# Altium schematic sheet Heart.SchDoc(Heart)
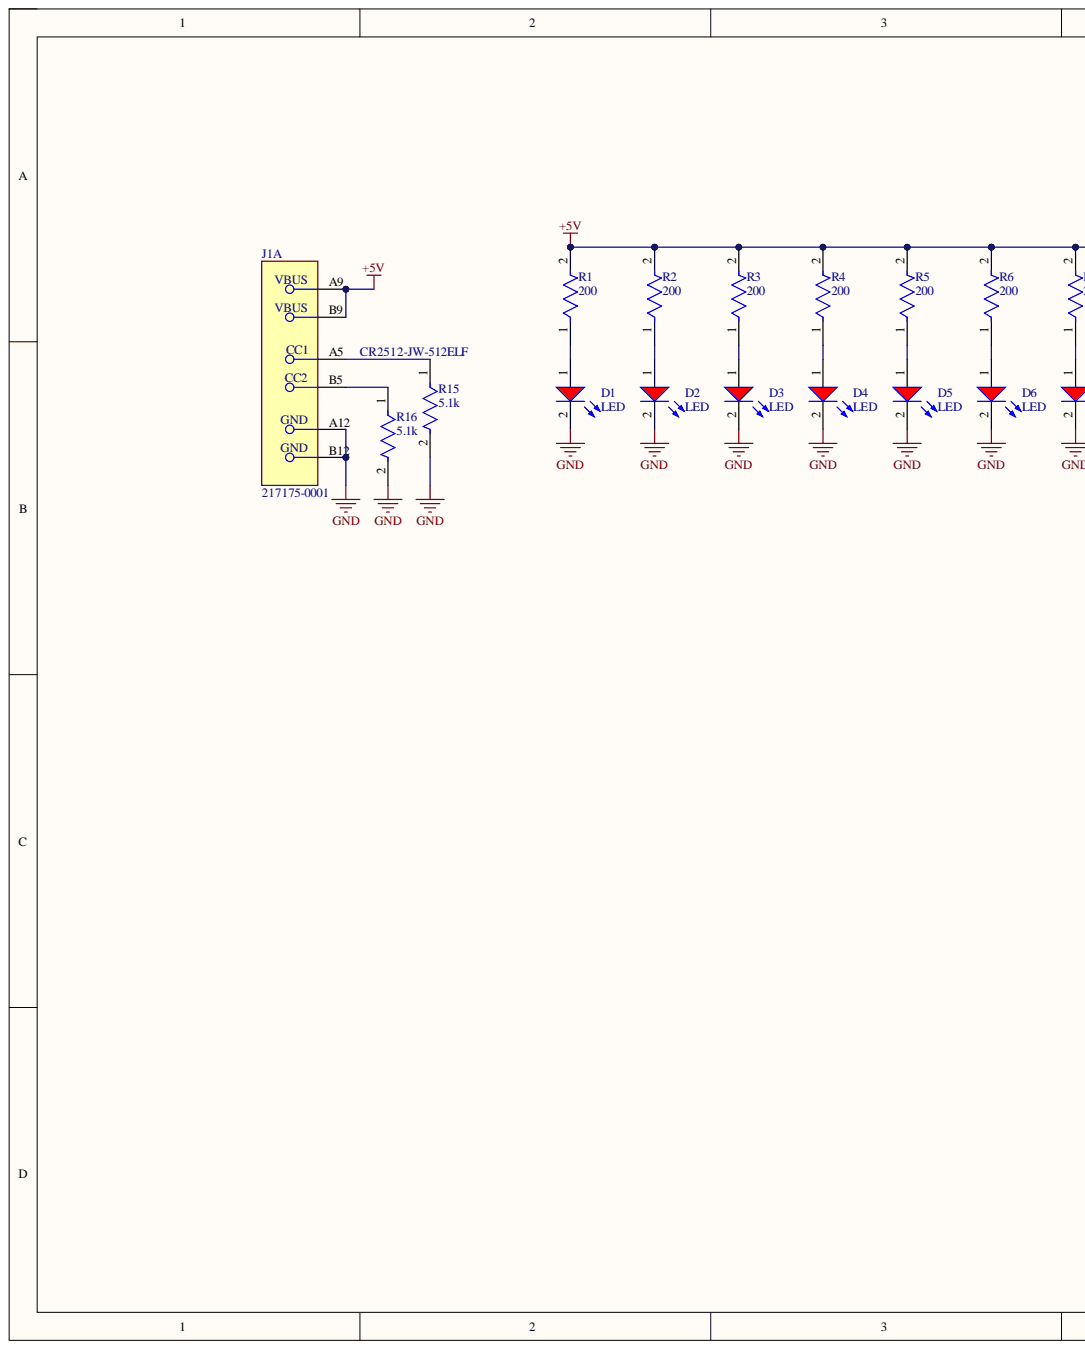
[diagram p0: Heart.SchDoc, left]
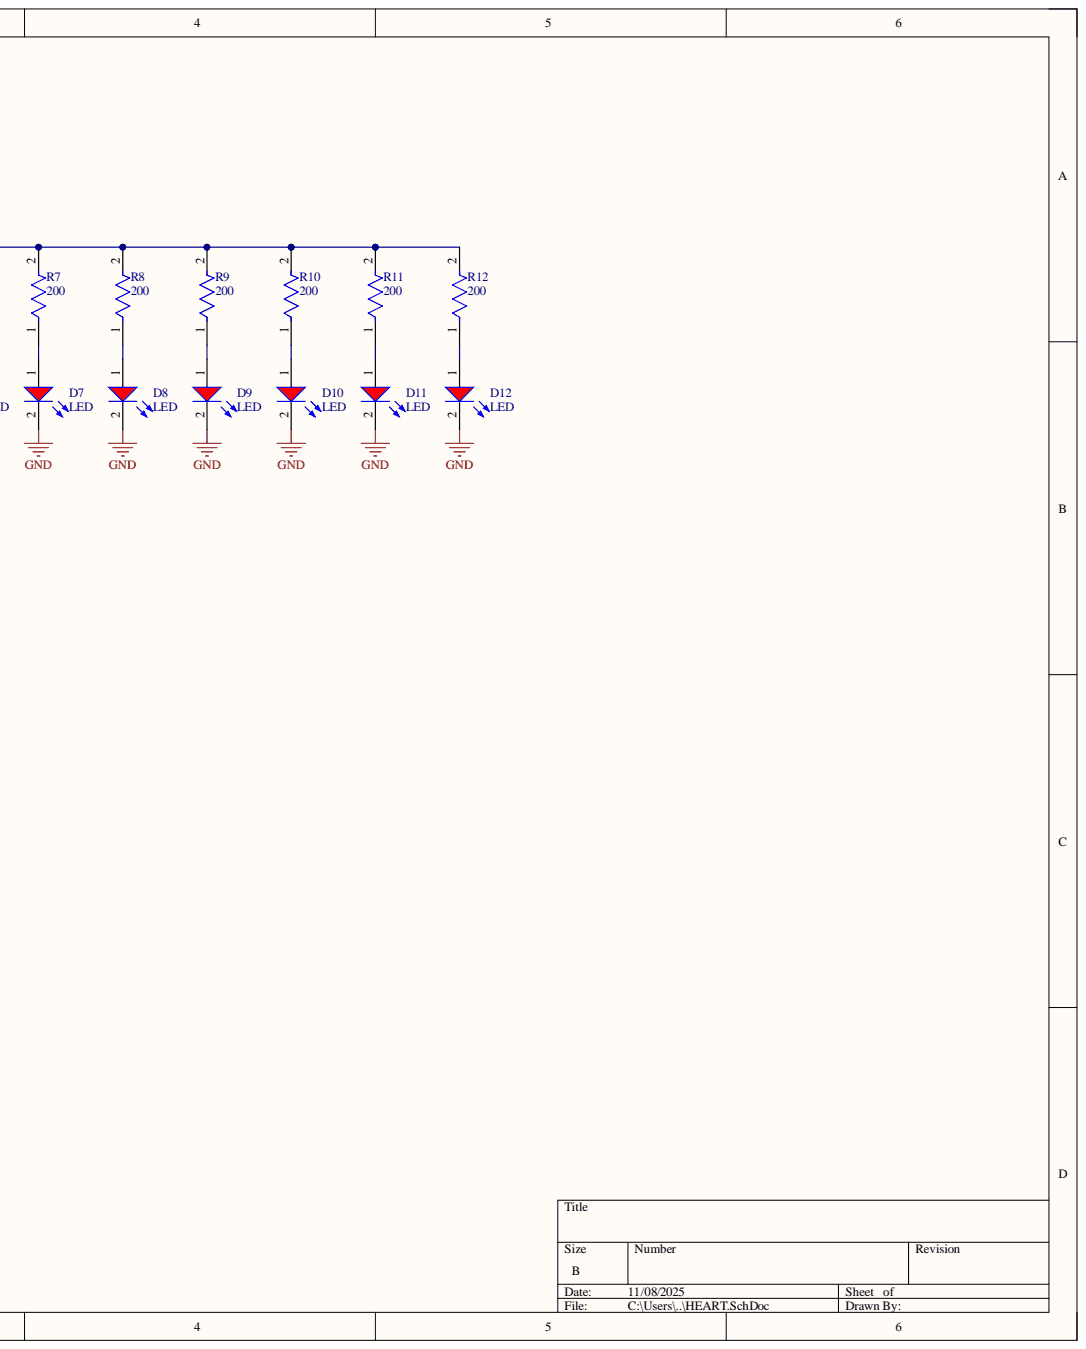
[diagram p1: Heart.SchDoc, right]

## Parts
D1  LED  [WL-TMRC_D3.95H5.3]
D2  LED  [WL-TMRC_D3.95H5.3]
D3  LED  [WL-TMRC_D3.95H5.3]
D4  LED  [WL-TMRC_D3.95H5.3]
D5  LED  [WL-TMRC_D3.95H5.3]
D6  LED  [WL-TMRC_D3.95H5.3]
D7  LED  [WL-TMRC_D3.95H5.3]
D8  LED  [WL-TMRC_D3.95H5.3]
D9  LED  [WL-TMRC_D3.95H5.3]
D10  LED  [WL-TMRC_D3.95H5.3]
D11  LED  [WL-TMRC_D3.95H5.3]
D12  LED  [WL-TMRC_D3.95H5.3]
J1  217175-0001  [FP-217175-0001-MFG]  (part A)
R1  200  [FP-TFCR1206-IPC_B]
R2  200  [FP-TFCR1206-IPC_B]
R3  200  [FP-TFCR1206-IPC_B]
R4  200  [FP-TFCR1206-IPC_B]
R5  200  [FP-TFCR1206-IPC_B]
R6  200  [FP-TFCR1206-IPC_B]
R7  200  [FP-TFCR1206-IPC_B]
R8  200  [FP-TFCR1206-IPC_B]
R9  200  [FP-TFCR1206-IPC_B]
R10  200  [FP-TFCR1206-IPC_B]
R11  200  [FP-TFCR1206-IPC_B]
R12  200  [FP-TFCR1206-IPC_B]
R15  5.1k  [FP-TFCR2512-IPC_A]
R16  5.1k  [FP-TFCR2512-IPC_A]

## Nets
+5V: J1.A9 J1.B9 R1.2 R2.2 R3.2 R4.2 R5.2 R6.2 R7.2 R8.2 R9.2 R10.2 R11.2 R12.2
GND: D1.2 D2.2 D3.2 D4.2 D5.2 D6.2 D7.2 D8.2 D9.2 D10.2 D11.2 D12.2 J1.A12 J1.B12 R15.2 R16.2
NetD1_1: D1.1 R1.1
NetD2_1: D2.1 R2.1
NetD3_1: D3.1 R3.1
NetD4_1: D4.1 R4.1
NetD5_1: D5.1 R5.1
NetD6_1: D6.1 R6.1
NetD7_1: D7.1 R7.1
NetD8_1: D8.1 R8.1
NetD9_1: D9.1 R9.1
NetD10_1: D10.1 R10.1
NetD11_1: D11.1 R11.1
NetD12_1: D12.1 R12.1
NetJ1_A5: J1.A5 R15.1
NetJ1_B5: J1.B5 R16.1

## Library data of the parts
D1-D12 = CMP-1488-00002-2: NexarPartId: 29717803; Color: Red; Dominant Wavelength: 640nm; Forward Current: 100mA; Forward Voltage: 2.2V; Lens Size: 3mm; Lens Style: Circular, Diffused, Round; Luminous Intensity: 35mcd; Max Reverse Voltage (DC): 5V; Peak Wavelength: 650nm; Test Current: 20mA; Viewing Angle: 60°; Wavelength: 630nm; Case/Package: Radial; Component Kind: Standard; Height: 5.3mm; Length: 3mm; Library Name: Altium Content Vault; Max Operating Temperature: 85°C; Min Operating Temperature: -40°C; Mount: Through Hole; Packaging: Bulk; REACH SVHC: Unknown; RoHS: Compliant; Simulation: diode; Width: 3mm
J1 = CMP-04767-000026-1: CONN RCP USB2.0 C 6+18P SMD RA; Component Kind: Standard; Library Name: Altium Content Vault; Simulation: R_Resistor_Default
R1-R12 = CMP-250906-243926-1: RES Thin Film, 100Ω, 0.1%, 0.125W, 25ppm/°C, 1206; Component Kind: Standard; Library Name: Altium Content Vault; Simulation: R_Resistor_Default
R15 R16 = CMP-250906-200568-1: RES Thin Film, 5.1kΩ, 0.5%, 0.5W, 25ppm/°C, 2512; Component Kind: Standard; Library Name: Altium Content Vault; Simulation: R_Resistor_Default
